# Altium schematic sheet EVK-NORA-W4_SCHEM_0002_NORA_Module.SchDoc
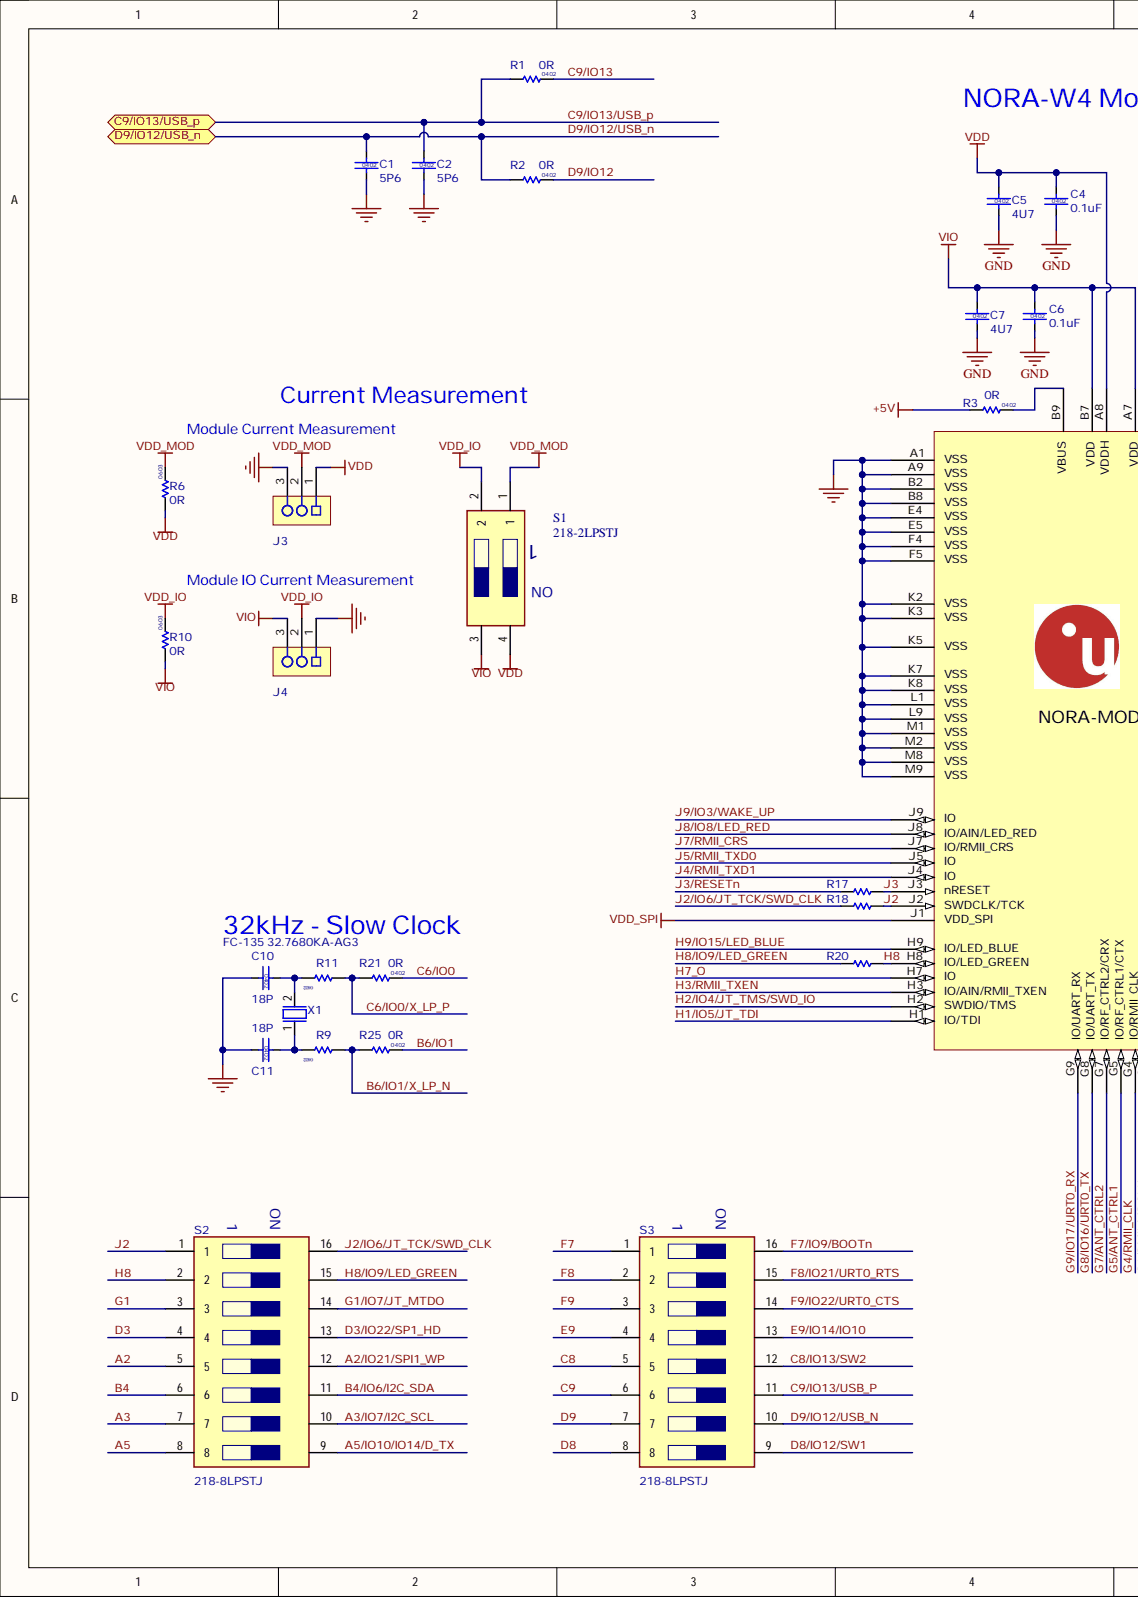
[diagram p0: EVK-NORA-W4_SCHEM_0002_NORA_Module.SchDoc, left]
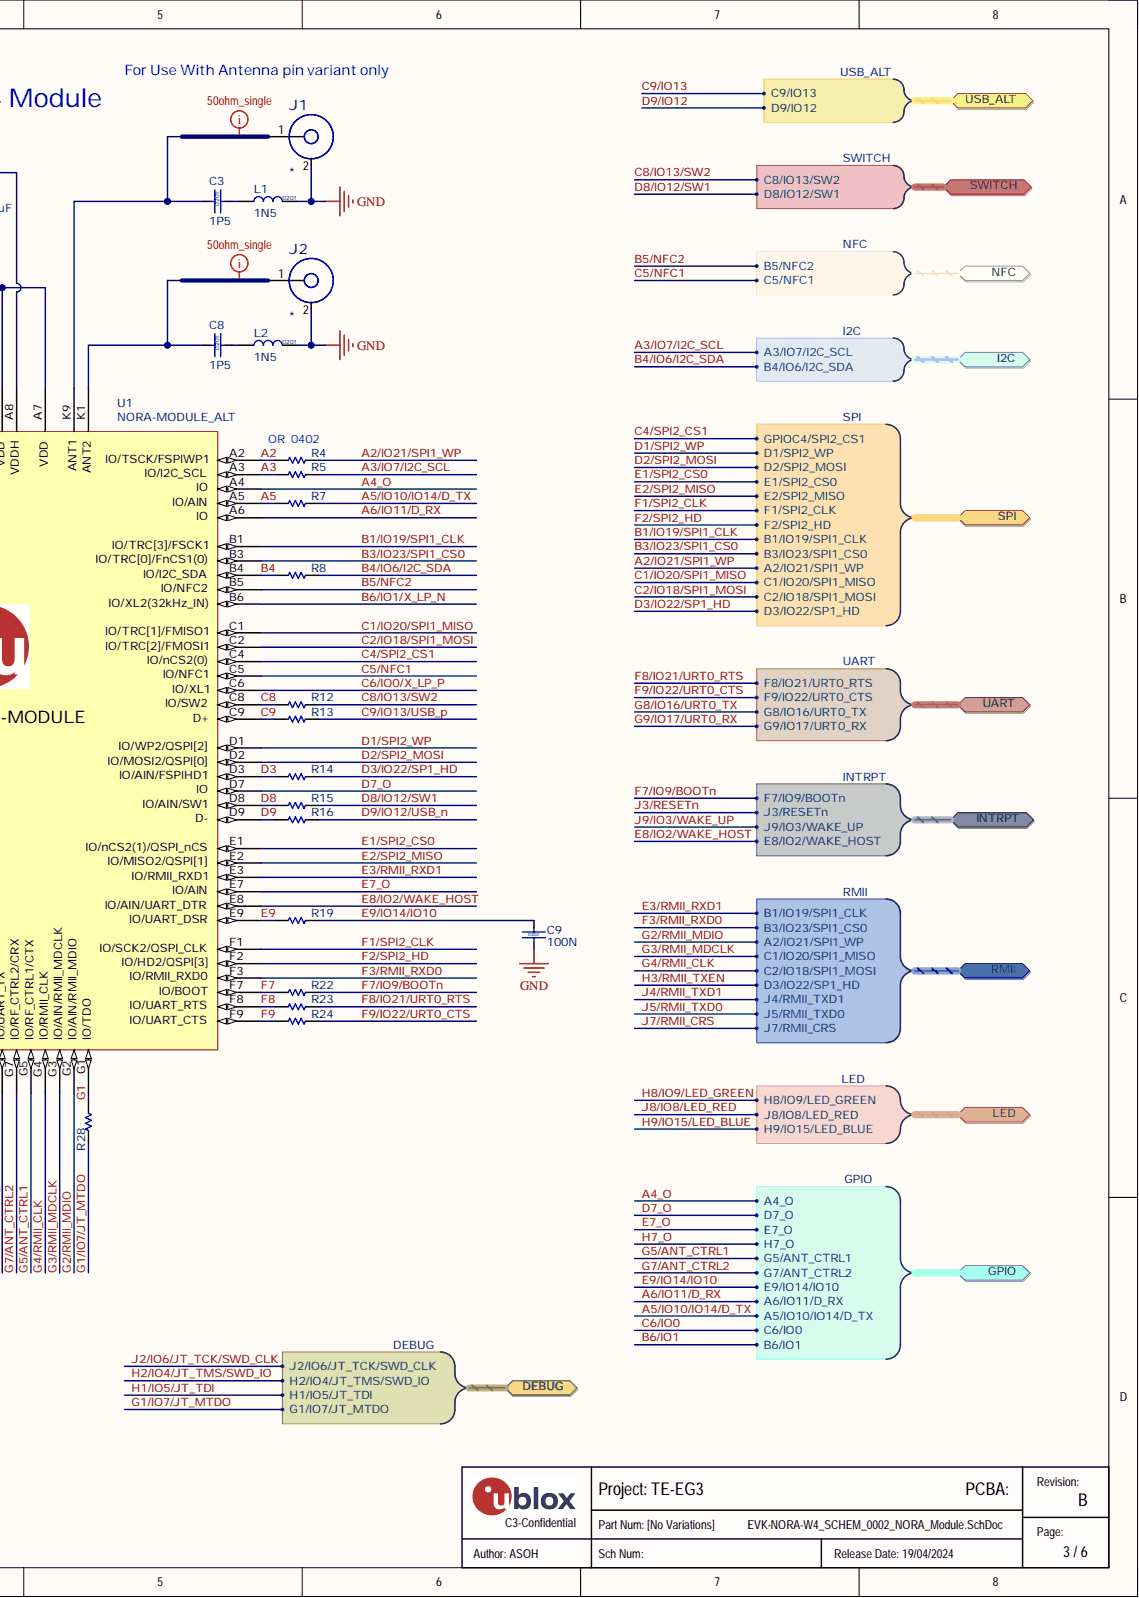
[diagram p1: EVK-NORA-W4_SCHEM_0002_NORA_Module.SchDoc, right]

## Parts
C1  value 5P6  Size: 0402  [Cap_0402_1100Lx600Wx600H_600x600Pad_350G_FLX]  (hidden comment: UBXH01-00040115P6)
C2  value 5P6  Size: 0402  [Cap_0402_1100Lx600Wx600H_600x600Pad_350G_FLX]  (hidden comment: UBXH01-00040115P6)
C3  value 1P5  Size: 0201  [Cap 0201 HD]  (hidden comment: UBXH01-00120021P5)
C4  value 0.1uF  Size: 0402  [Cap 0402 HD]  (hidden comment: 120-000160.1uF)
C5  value 4U7  Size: 0402  [Cap 0402 HD]  (hidden comment: UBXH01-00002434U7)
C6  value 0.1uF  Size: 0402  [Cap 0402 HD]  (hidden comment: 120-000160.1uF)
C7  value 4U7  Size: 0402  [Cap 0402 HD]  (hidden comment: UBXH01-00002434U7)
C8  value 1P5  Size: 0201  [Cap 0201 HD]  (hidden comment: UBXH01-00120021P5)
C9  value 100N  Size: 0402  [Cap 0402 HD]  (hidden comment: UBXH01-2021002100N)
C10  value 18P  Size: 0402  [Cap_0402_1100Lx600Wx600H_600x600Pad_350G_FLX]  (hidden comment: UBXH01-000401718P)
C11  value 18P  Size: 0402  [Cap_0402_1100Lx600Wx600H_600x600Pad_350G_FLX]  (hidden comment: UBXH01-000401718P)
J1  130-00067  [U.FL_3Pin]  (value not drawn)
J2  130-00067  [U.FL_3Pin]  (value not drawn)
J3  UBXH04-0000792  [HDR-0.1P-3Pin-1Row-SMT]  (value not drawn)
J4  UBXH04-0000792  [HDR-0.1P-3Pin-1Row-SMT]  (value not drawn)
L1  value 1N5  Size: 0201  [IND_0201_630Lx330Wx330H_300x300Pad_250G_FLX]  (hidden comment: UBXH09-00000301N5)
L2  value 1N5  Size: 0201  [IND_0201_630Lx330Wx330H_300x300Pad_250G_FLX]  (hidden comment: UBXH09-00000301N5)
R1  value 0R  Size: 0402  [RES 0402 HD]  (hidden comment: UBXH18-00030020R)
R2  value 0R  Size: 0402  [RES 0402 HD]  (hidden comment: UBXH18-00030020R)
R3  value 0R  Size: 0402  [RES 0402 HD]  (hidden comment: UBXH18-00030020R)
R4  Size: 0402  [RES 0402 HD]  (hidden comment: UBXH18-00030020R)
R5  UBXH18-00030020R  [RES 0402 HD]  (value not drawn)
R6  value 0R  Size: 0603  [RES_0603_1700Lx950Wx600H_600x1000Pad_800G_FLX]  (hidden comment: UBXH18-00030010R)
R7  UBXH18-00030020R  [RES 0402 HD]  (value not drawn)
R8  UBXH18-00030020R  [RES 0402 HD]  (value not drawn)
R9  Size: 0402  [RES_0402_1100Lx600Wx400H_500x600Pad_400G_FLX]  (hidden comment: UBXH18-00030020R)
R10  value 0R  Size: 0603  [RES_0603_1700Lx950Wx600H_600x1000Pad_800G_FLX]  (hidden comment: UBXH18-00030010R)
R11  Size: 0402  [RES_0402_1100Lx600Wx400H_500x600Pad_400G_FLX]  (hidden comment: UBXH18-00030020R)
R12  UBXH18-00030020R  [RES 0402 HD]  (value not drawn)
R13  UBXH18-00030020R  [RES 0402 HD]  (value not drawn)
R14  UBXH18-00030020R  [RES_0402_1100Lx600Wx400H_500x600Pad_400G_FLX]  (value not drawn)
R15  UBXH18-00030020R  [RES 0402 HD]  (value not drawn)
R16  UBXH18-00030020R  [RES 0402 HD]  (value not drawn)
R17  UBXH18-00030020R  [RES_0402_1100Lx600Wx400H_500x600Pad_400G_FLX]  (value not drawn)
R18  UBXH18-00030020R  [RES 0402 HD]  (value not drawn)
R19  UBXH18-00030020R  [RES 0402 HD]  (value not drawn)
R20  UBXH18-00030020R  [RES 0402 HD]  (value not drawn)
R21  value 0R  Size: 0402  [RES 0402 HD]  (hidden comment: UBXH18-00030020R)
R22  UBXH18-00030020R  [RES 0402 HD]  (value not drawn)
R23  UBXH18-00030020R  [RES 0402 HD]  (value not drawn)
R24  UBXH18-00030020R  [RES 0402 HD]  (value not drawn)
R25  value 0R  Size: 0402  [RES 0402 HD]  (hidden comment: UBXH18-00030020R)
R28  UBXH18-00030020R  [RES 0402 HD]  (value not drawn)
S1  218-2LPSTJ  [2182LPSTJ]
S2  218-8LPSTJ  [2188LPSTJ]
S3  218-8LPSTJ  [2188LPSTJ]
U1  NORA-MODULE_ALT  [NORA_LGA82R_1430X1040X180_ANT]
X1  100-00005  [XTAL_3215]  (value not drawn)

## Ports
C9/IO13/USB_p, D9/IO12/USB_n, DEBUG, DEBUG.G1/IO7/JT_MTDO, DEBUG.H1/IO5/JT_TDI, DEBUG.H2/IO4/JT_TMS/SWD_IO, DEBUG.J2/IO6/JT_TCK/SWD_CLK, GPIO, GPIO.A4_O, GPIO.A5/IO10/IO14/D_TX, GPIO.A6/IO11/D_RX, GPIO.B6/IO1, GPIO.C6/IO0, GPIO.D7_O, GPIO.E7_O, GPIO.E9/IO14/IO10, GPIO.G5/ANT_CTRL1, GPIO.G7/ANT_CTRL2, GPIO.H7_O, I2C, I2C.A3/IO7/I2C_SCL, I2C.B4/IO6/I2C_SDA, INTRPT, INTRPT.E8/IO2/WAKE_HOST, INTRPT.F7/IO9/BOOTn, INTRPT.J3/RESETn, INTRPT.J9/IO3/WAKE_UP, LED, LED.H8/IO9/LED_GREEN, LED.H9/IO15/LED_BLUE, LED.J8/IO8/LED_RED, NFC, NFC.B5/NFC2, NFC.C5/NFC1, RMII, RMII.A2/IO21/SPI1_WP, RMII.B1/IO19/SPI1_CLK, RMII.B3/IO23/SPI1_CS0, RMII.C1/IO20/SPI1_MISO, RMII.C2/IO18/SPI1_MOSI, RMII.D3/IO22/SP1_HD, RMII.J4/RMII_TXD1, RMII.J5/RMII_TXD0, RMII.J7/RMII_CRS, SPI, SPI.A2/IO21/SPI1_WP, SPI.B1/IO19/SPI1_CLK, SPI.B3/IO23/SPI1_CS0, SPI.C1/IO20/SPI1_MISO, SPI.C2/IO18/SPI1_MOSI, SPI.D1/SPI2_WP, SPI.D2/SPI2_MOSI, SPI.D3/IO22/SP1_HD, SPI.E1/SPI2_CS0, SPI.E2/SPI2_MISO, SPI.F1/SPI2_CLK, SPI.F2/SPI2_HD, SPI.GPIOC4/SPI2_CS1, SWITCH, SWITCH.C8/IO13/SW2, SWITCH.D8/IO12/SW1, UART, UART.F8/IO21/URT0_RTS, UART.F9/IO22/URT0_CTS, UART.G8/IO16/URT0_TX, UART.G9/IO17/URT0_RX, USB_ALT, USB_ALT.C9/IO13, USB_ALT.D9/IO12

## Nets
+5V: R3.1
GND: C1.2 C2.2 C4.2 C5.2 C6.2 C7.2 C9.1 C10.2 C11.2 J1.2 J2.2 J3.3 J4.1 L1.2 L2.2 U1.A1(VSS) U1.A9(VSS) U1.B2(VSS) U1.B8(VSS) U1.E4(VSS) U1.E5(VSS) U1.F4(VSS) U1.F5(VSS) U1.K2(VSS) U1.K3(VSS) U1.K5(VSS) U1.K7(VSS) U1.K8(VSS) U1.L1(VSS) U1.L9(VSS) U1.M1(VSS) U1.M2(VSS) U1.M8(VSS) U1.M9(VSS)
VDD: C4.1 C5.1 J3.1 R6.1 S1.4 U1.A8(VDDH)
VDD_IO: J4.2 R10.2 S1.2
VDD_MOD: J3.2 R6.2 S1.1
VDD_SPI: U1.J1(VDD_SPI)
VIO: C6.1 C7.1 J4.3 R10.1 S1.3 U1.A7(VDD) U1.B7(VDD)
A2: R4.2 S2.5 U1.A2(IO/TSCK/FSPIWP1)
A2/IO21/SPI1_WP: R4.1 S2.12 ; port SPI
A3: R5.2 S2.7 U1.A3(IO/I2C_SCL)
A3/IO7/I2C_SCL: R5.1 S2.10 ; port I2C
A4_O: U1.A4(IO) ; port GPIO
A5: R7.2 S2.8 U1.A5(IO/AIN)
A5/IO10/IO14/D_TX: R7.1 S2.9 ; port GPIO
A6/IO11/D_RX: U1.A6(IO) ; port GPIO
B1/IO19/SPI1_CLK: U1.B1(IO/TRC[3]/FSCK1) ; port SPI
B3/IO23/SPI1_CS0: U1.B3(IO/TRC[0]/FnCS1(0)) ; port SPI
B4: R8.2 S2.6 U1.B4(IO/I2C_SDA)
B4/IO6/I2C_SDA: R8.1 S2.11 ; port I2C
B5/NFC2: U1.B5(IO/NFC2) ; port NFC
B6/IO1: R25.2 ; port GPIO
B6/IO1/X_LP_N: R9.1 R25.1 U1.B6(IO/XL2(32kHz_IN))
C1/IO20/SPI1_MISO: U1.C1(IO/TRC[1]/FMISO1) ; port SPI
C2/IO18/SPI1_MOSI: U1.C2(IO/TRC[2]/FMOSI1) ; port SPI
C4/SPI2_CS1: U1.C4(IO/nCS2(0)) ; port SPI
C5/NFC1: U1.C5(IO/NFC1) ; port NFC
C6/IO0: R21.2 ; port GPIO
C6/IO0/X_LP_P: R11.1 R21.1 U1.C6(IO/XL1)
C8: R12.2 S3.5 U1.C8(IO/SW2)
C8/IO13/SW2: R12.1 S3.12 ; port SWITCH
C9: R13.2 S3.6 U1.C9(D+)
C9/IO13: R1.2 ; port USB_ALT
C9/IO13/USB_p: C2.1 R1.1 R13.1 S3.11 ; port C9/IO13/USB_p
D1/SPI2_WP: U1.D1(IO/WP2/QSPI[2]) ; port SPI
D2/SPI2_MOSI: U1.D2(IO/MOSI2/QSPI[0]) ; port SPI
D3: R14.2 S2.4 U1.D3(IO/AIN/FSPIHD1)
D3/IO22/SP1_HD: R14.1 S2.13 ; port SPI
D7_O: U1.D7(IO) ; port GPIO
D8: R15.2 S3.8 U1.D8(IO/AIN/SW1)
D8/IO12/SW1: R15.1 S3.9 ; port SWITCH
D9: R16.2 S3.7 U1.D9(D-)
D9/IO12: R2.2 ; port USB_ALT
D9/IO12/USB_n: C1.1 R2.1 R16.1 S3.10 ; port D9/IO12/USB_n
E1/SPI2_CS0: U1.E1(IO/nCS2(1)/QSPI_nCS) ; port SPI
E2/SPI2_MISO: U1.E2(IO/MISO2/QSPI[1]) ; port SPI
E3/RMII_RXD1: U1.E3(IO/RMII_RXD1) ; port RMII
E7_O: U1.E7(IO/AIN) ; port GPIO
E8/IO2/WAKE_HOST: U1.E8(IO/AIN/UART_DTR) ; port INTRPT
E9: R19.2 S3.4 U1.E9(IO/UART_DSR)
E9/IO14/IO10: C9.2 R19.1 S3.13 ; port GPIO
F1/SPI2_CLK: U1.F1(IO/SCK2/QSPI_CLK) ; port SPI
F2/SPI2_HD: U1.F2(IO/HD2/QSPI[3]) ; port SPI
F3/RMII_RXD0: U1.F3(IO/RMII_RXD0) ; port RMII
F7: R22.2 S3.1 U1.F7(IO/BOOT)
F7/IO9/BOOTn: R22.1 S3.16 ; port INTRPT
F8: R23.2 S3.2 U1.F8(IO/UART_RTS)
F8/IO21/URT0_RTS: R23.1 S3.15 ; port UART
F9: R24.2 S3.3 U1.F9(IO/UART_CTS)
F9/IO22/URT0_CTS: R24.1 S3.14 ; port UART
G1: R28.1 S2.3 U1.G1(IO/TDO)
G1/IO7/JT_MTDO: R28.2 S2.14 ; port DEBUG
G2/RMII_MDIO: U1.G2(IO/AIN/RMII_MDIO) ; port RMII
G3/RMII_MDCLK: U1.G3(IO/AIN/RMII_MDCLK) ; port RMII
G4/RMII_CLK: U1.G4(IO/RMII_CLK) ; port RMII
G5/ANT_CTRL1: U1.G5(IO/RF_CTRL1/CTX) ; port GPIO
G7/ANT_CTRL2: U1.G7(IO/RF_CTRL2/CRX) ; port GPIO
G8/IO16/URT0_TX: U1.G8(IO/UART_TX) ; port UART
G9/IO17/URT0_RX: U1.G9(IO/UART_RX) ; port UART
H1/IO5/JT_TDI: U1.H1(IO/TDI) ; port DEBUG
H2/IO4/JT_TMS/SWD_IO: U1.H2(SWDIO/TMS) ; port DEBUG
H3/RMII_TXEN: U1.H3(IO/AIN/RMII_TXEN) ; port RMII
H7_O: U1.H7(IO) ; port GPIO
H8: R20.1 S2.2 U1.H8(IO/LED_GREEN)
H8/IO9/LED_GREEN: R20.2 S2.15 ; port LED
H9/IO15/LED_BLUE: U1.H9(IO/LED_BLUE) ; port LED
J2: R18.1 S2.1 U1.J2(SWDCLK/TCK)
J2/IO6/JT_TCK/SWD_CLK: R18.2 S2.16 ; port DEBUG
J3: R17.1 U1.J3(nRESET)
J3/RESETn: R17.2 ; port INTRPT
J4/RMII_TXD1: U1.J4(IO) ; port RMII
J5/RMII_TXD0: U1.J5(IO) ; port RMII
J7/RMII_CRS: U1.J7(IO/RMII_CRS) ; port RMII
J8/IO8/LED_RED: U1.J8(IO/AIN/LED_RED) ; port LED
J9/IO3/WAKE_UP: U1.J9(IO) ; port INTRPT
NetC3_1: C3.1 J1.1 U1.K9(ANT1)
NetC3_2: C3.2 L1.1
NetC8_1: C8.1 J2.1 U1.K1(ANT2)
NetC8_2: C8.2 L2.1
NetC10_1: C10.1 R11.2 X1.2
NetC11_1: C11.1 R9.2 X1.1
NetR3_2: R3.2 U1.B9(VBUS)

## Library data of the parts
C1 C2 = UBXH01-0004011: CAP CER C0G 0402 5P6 +/-0.25P 25V; Manufacturer: Murata Manufacturing Company, Ltd.; Manufacturer P/N: GRM1555C1H5R6CZ01; Database Table Name: Passive - Capacitor; Editor: roland.rust; Env: ROHS; Mfg P/N Released: Obsolete; Mfg P/N Split: 0; Perferred: PREF; Permitted Use: Volume Products; Component Kind: Standard; Library Name: UBX_Parts_DB_Lib.DbLib; Manufacturer 2: Murata Manufacturing Company, Ltd.; Manufacturer P/N 2: GRM1555C1E5R6CZ01; Max Voltage: 25V; Mfg P/N Released 2: Obsolete; Mfg P/N Split 2: 0; Mfg P/N Status: Obsolete; Mfg P/N Status 2: Obsolete; Part Number: UBXH01-0004011; Part Type: Capacitor; RefDes: C; Release Data: 2007-10-22T12:46:36; Signal Integrity: Cap Semi; Simulation: CAP; Tolerance: +/-0.25P; UBX Wiki: https://wiki.u-blox.com/bin/view/Operations/PartDetails?index=5160; Released by: roland.rust
C3 C8 = UBXH01-0012002: CAP CER C0G 0201 1P5 +/-0.25P 25V; Manufacturer: Murata Manufacturing Company, Ltd.; Manufacturer P/N: GRM0335C1E1R5CA01; Database Table Name: Passive - Capacitor; Editor: roland.rust; Env: ROHS; Mfg P/N Released: Yes; Mfg P/N Split: 100; Mfg P/N Status: Active; Perferred: PREF; Permitted Use: Volume Products; Component Kind: Standard; Library Name: UBX_Parts_DB_Lib.DbLib; Manufacturer 2: Murata Manufacturing Company, Ltd.; Manufacturer P/N 2: GRM0335C1E1R5C; Max Voltage: 25V; Mfg P/N Released 2: Obsolete; Mfg P/N Split 2: 0; Mfg P/N Status 2: Active; Part Number: UBXH01-0012002; Part Type: Capacitor; RefDes: C; Release Data: 2009-03-23T10:34:14; Signal Integrity: Cap Semi; Simulation: CAP; Tolerance: +/-0.25P; UBX Wiki: https://wiki.u-blox.com/bin/view/Operations/PartDetails?index=5072; Released by: roland.rust
C4 C6 = 120-00016: Cap, Ceramic 0.1uF 10V 10% X5R 0402; Manufacturer: Murata Electronics North America; Manufacturer P/N: GRM155R61A104KA01J; Part Name: CAP CER 0.1UF 10V 10% X5R 0402; Component Mounting Type: SMT; Database Table Name: Ceramic Capacitor; Item_Phase: Production; Tolerance: +/- 10%; Use in new designs: Yes; Arena Link: https://app.bom.com/a/GYHPV2L2L43KRMBK?v=spec.summary; Component Kind: Standard; Height: 0.55mm; Manufacturer 2: Yageo; Manufacturer P/N 2: CC0402KRX5R6BB104; Part Number: 120-00016; Part_Type: Ceramic Capacitor; Pins: 2; Revision: 0A; Signal Integrity: Cap Semi; Simulation: CAP; Supplier 1: Digi-Key; Supplier 2: Digi-Key; Supplier Part Number 1: 490-6297-1-ND; Supplier Part Number 2: 311-1336-1-ND; Temperature Coefficient: X5R; Voltage: 10V; Library Name: Arena_Parts_DB_Lib.DbLib
C5 C7 = UBXH01-0000243: CAP CER X5R 0402 4U7 20% 10V; Manufacturer: Murata Manufacturing Company, Ltd.; Manufacturer P/N: GRM155R61A475MEAA; Database Table Name: Passive - Capacitor; Editor: martin.pilik; Env: GREEN; Mfg P/N Released: Yes; Mfg P/N Split: 100; Mfg P/N Status: Active; Perferred: PREF; Permitted Use: Volume Products; Released by: fabrice.bret-dibat; Component Kind: Standard; Library Name: UBX_Parts_DB_Lib.DbLib; Max Operating Temp: 85°C; Max Voltage: 10V; Mfg P/N Datasheet: https://wiki.u-blox.com/bin/view/Generic/Docs/DocumentSummary?index=24302; Min Operating Temp: -40°C; Part Number: UBXH01-0000243; Part Type: Capacitor; RefDes: C; Release Data: 2021-05-10 12:57:47.325652; Signal Integrity: Cap Semi; Simulation: CAP; Tolerance: 20%; UBX Wiki: https://wiki.u-blox.com/bin/view/Operations/PartDetails?index=24303
C9 = UBXH01-2021002: CAP CER X5R 0402 100N 10% 10V; Manufacturer: Murata Manufacturing Company, Ltd.; Manufacturer P/N: GRM155R61A104KA01; Database Table Name: Passive - Capacitor; Editor: roland.rust; Env: GREEN; Mfg P/N Released: Yes; Mfg P/N Split: 100; Mfg P/N Status: NRND; Perferred: PREF; Permitted Use: Volume Products; Component Kind: Standard; Library Name: UBX_Parts_DB_Lib.DbLib; Manufacturer 2: Samsung Electro-Mechanics; Manufacturer P/N 2: CL05A104KP5NNN; Max Voltage: 10V; Mfg P/N Datasheet 2: https://wiki.u-blox.com/bin/view/Generic/Docs/DocumentSummary?index=28113; Mfg P/N Released 2: Yes; Mfg P/N Split 2: 0; Mfg P/N Status 2: Active; Part Number: UBXH01-2021002; Part Type: Capacitor; RefDes: C; Release Data: 2005-07-22T13:59:44; Signal Integrity: Cap Semi; Simulation: CAP; Tolerance: 10%; UBX Wiki: https://wiki.u-blox.com/bin/view/Operations/PartDetails?index=5759; Released by: roland.rust
C10 C11 = UBXH01-0004017: CAP CER C0G 0402 18P 5% 25V; Manufacturer: Murata Manufacturing Company, Ltd.; Manufacturer P/N: GRM1555C1H180JA01; Database Table Name: Passive - Capacitor; Editor: doris.zahnd; Env: ROHS; Mfg P/N Released: Yes; Mfg P/N Split: 100; Mfg P/N Status: NRND; Perferred: PREF; Permitted Use: Volume Products; Released by: daniel.hurni; Component Kind: Standard; Library Name: UBX_Parts_DB_Lib.DbLib; Manufacturer 2: Murata Manufacturing Company, Ltd.; Manufacturer 3: Murata Manufacturing Company, Ltd.; Manufacturer P/N 2: GRM1555C1E180JZ01; Manufacturer P/N 3: GRM1555C1H180JZ01; Max Operating Temp: 125°C; Max Voltage: 25V; Mfg P/N Released 2: Yes; Mfg P/N Released 3: Yes; Mfg P/N Split 2: 0; Mfg P/N Split 3: 0; Mfg P/N Status 2: Obsolete; Mfg P/N Status 3: Obsolete; Min Operating Temp: -55°C; Part Number: UBXH01-0004017; Part Type: Capacitor; RefDes: C; Release Data: 2009-12-03T17:48:42; Signal Integrity: Cap Semi; Simulation: CAP; Tolerance: 5%; UBX Wiki: https://wiki.u-blox.com/bin/view/Operations/PartDetails?index=5178
J1 J2 = 130-00067: U.FL jack, SMA 50 ohm, 3 pin, SMT; Manufacturer 1: Hirose Electric Co Ltd; Manufacturer Part Number 1: U.FL-R-SMT(10); Part Name: CON RF UMC U.FL Jack 3Pin SMT; Database Table Name: Connector; Item Phase: In Production; UBX Code: UBXH04-0001026; Use in new designs: Yes; Voltage: None; Component Kind: Standard; Diameter: None; Height: 1.25mm; Manufacturer 2: Dongguan Qintai Electronics Co, Ltd.; Manufacturer Part Number 2: MHF-RT-1; Max Operating Temp: 90; Min Operating Temp: -40; Part Number: 130-00067; Pins: 3; Revision: 0A; Supplier 1: Digi-Key; Supplier 2: Rayson Technology co., ltd; Supplier Part Number 1: H9161CT-ND; Supplier Part Number 2: MHF-RT-1; Library Name: Arena_Parts_DB_Lib.DbLib
J3 J4 = UBXH04-0000792: 1-ROW SMD CONN 100MIL GRID 3 -PIN 100V 3A; Manufacturer: HARWiN; Manufacturer P/N: M20-8770342; Database Table Name: Electromechanical - Connector; Editor: eric.moog; Env: ROHS; Mfg P/N Released: No; Mfg P/N Split: 100; Mfg P/N Status: Active; Permitted Use: Support Products; Value: M20-8770342; Component Kind: Standard; Library Name: UBX_Parts_DB_Lib.DbLib; Max Current: 3A; Max Operating Temp: 105°C; Max Voltage: 100V; Mfg P/N Datasheet: https://wiki.u-blox.com/bin/view/Generic/Docs/DocumentSummary?index=28457; Min Operating Temp: -40°C; Part Number: UBXH04-0000792; Part Type: Connector; Perferred: N/A; RefDes: J; UBX Wiki: https://wiki.u-blox.com/bin/view/Operations/PartDetails?index=38569
L1 L2 = UBXH09-0000030: IND MURATA LQP03T_02 0201 1N5 +/-0.1N 600mA; Manufacturer: Murata Manufacturing Company, Ltd.; Manufacturer P/N: LQP03TN1N5B02; Database Table Name: Passive - Inductor; Editor: massimo.pros; Env: ROHS; Mfg P/N Released: Yes; Mfg P/N Split: 100; Mfg P/N Status: Active; Perferred: PREF; Permitted Use: Volume Products; Released by: massimo.vlacci; Component Kind: Standard; Library Name: UBX_Parts_DB_Lib.DbLib; Max Current: 600mA; Mfg P/N Datasheet: https://wiki.u-blox.com/bin/view/Generic/Docs/DocumentSummary?index=11499; Part Number: UBXH09-0000030; Part Type: Inductor; RefDes: L; Release Data: 2011-06-21T11:29:10; Tolerance: +/-0.1N; UBX Wiki: https://wiki.u-blox.com/bin/view/Operations/PartDetails?index=13044
R1-R3 R9 R11 R14 R17 R21 R25 = UBXH18-0003002: RES THICK FILM CHIP 0402 0R 0 100mW; Manufacturer: KOA; Manufacturer P/N: RK73Z1ETTD; Database Table Name: Passive - Resistor; Editor: charlotte.biber; Env: ROHS; Mfg P/N Released: Yes; Mfg P/N Split: 100; Mfg P/N Status: NRND; Perferred: PREF; Permitted Use: Volume Products; Released by: peter.mueller; Tolerance: 0; Component Kind: Standard; Library Name: UBX_Parts_DB_Lib.DbLib; Manufacturer 2: YAGEO; Manufacturer P/N 2: 2322_705_91001_L; Max Power: 100mW; Mfg P/N Released 2: Yes; Mfg P/N Split 2: 0; Part Number: UBXH18-0003002; Part Type: Resistor; RefDes: R; Signal Integrity: Res; Simulation: RESISTOR; UBX Wiki: https://wiki.u-blox.com/bin/view/Operations/PartDetails?index=6611
  R1-R3 R21 R25: Mfg P/N Status 2: NRND; Release Data: 2012-06-05 09:49:55.978616
  R9 R11: Mfg P/N Status 2: Obsolete; Release Data: 2012-06-05T09:49:55; Value: 0R
  R14 R17: Mfg P/N Status 2: Obsolete; Release Data: 2012-06-05T09:49:55; Size: 0402; Value: 0R
R4 R5 R7 R8 R12 R13 R15 R16 R18-R20 R22-R24 R28 = UBXH18-0003002: RES THICK FILM CHIP 0402 0R 0 100mW; Manufacturer: KOA; Manufacturer P/N: RK73Z1ETTP; Database Table Name: Passive - Resistor; Editor: charlotte.biber; Env: ROHS; Mfg P/N Released: Yes; Mfg P/N Split: 100; Mfg P/N Status: NRND; Perferred: PREF; Permitted Use: Volume Products; Released by: peter.mueller; Tolerance: 0; Component Kind: Standard; Library Name: UBX_Parts_DB_Lib.DbLib; Manufacturer 2: YAGEO; Manufacturer P/N 2: 2322_705_91001_L; Max Power: 100mW; Mfg P/N Released 2: Yes; Mfg P/N Split 2: 0; Mfg P/N Status 2: NRND; Part Number: UBXH18-0003002; Part Type: Resistor; RefDes: R; Release Data: 2012-06-05 09:49:55.978616; Signal Integrity: Res; Simulation: RESISTOR; UBX Wiki: https://wiki.u-blox.com/bin/view/Operations/PartDetails?index=6611; Value: 0R
  R5 R7 R8 R12 R13 R15 R16 R18-R20 R22-R24 R28: Size: 0402
R6 R10 = UBXH18-0003001: RES THICK FILM CHIP 0603 0R 0 100mW; Manufacturer: YAGEO; Manufacturer P/N: RC0603JR-070RL; Database Table Name: Passive - Resistor; Editor: martin.frauchiger; Env: ROHS; Mfg P/N Released: Yes; Mfg P/N Split: 100; Mfg P/N Status: Active; Perferred: PREF; Permitted Use: Volume Products; Tolerance: 0; Component Kind: Standard; Library Name: UBX_Parts_DB_Lib.DbLib; Max Power: 100mW; Part Number: UBXH18-0003001; Part Type: Resistor; RefDes: R; Release Data: 2005-07-14T10:55:51; Signal Integrity: Res; Simulation: RESISTOR; UBX Wiki: https://wiki.u-blox.com/bin/view/Operations/PartDetails?index=6609; Released by: martin.frauchiger
S1 = 218-2LPSTJ: Switch; Manufacturer_Name: CTS; Arrow Part Number: 218-2LPSTJ; Arrow Price/Stock: https://www.arrow.com/en/products/218-2lpstj/cts; Component Kind: Standard; Datasheet Link: https://www.ctscorp.com/wp-content/uploads/218.pdf; Height: 2.7mm; Library Name: SamacSys.SchLib; Manufacturer_Part_Number: 218-2LPSTJ; Mouser Part Number: 774-2182LPSTJ; Mouser Price/Stock: https://www.mouser.co.uk/ProductDetail/CTS-Electronic-Components/218-2LPSTJ?qs=qNzHFtQhdJ8h31nbRNBSWA%3D%3D
S2 S3 = 218-8LPSTJ: Switch; Manufacturer_Name: CTS; Arrow Part Number: 218-8LPSTJ; Arrow Price/Stock: https://www.arrow.com/en/products/218-8lpstj/cts; Component Kind: Standard; Datasheet Link: https://www.ctscorp.com/wp-content/uploads/218.pdf; Height: 2.7mm; Library Name: SamacSys.SchLib; Manufacturer_Part_Number: 218-8LPSTJ; Mouser Part Number: 774-2188LPSTJ; Mouser Price/Stock: https://www.mouser.co.uk/ProductDetail/CTS-Electronic-Components/218-8LPSTJ?qs=hDoPxpGr7lfoBDyeqUpd9g%3D%3D
U1 = NORA-MODULE_ALT: u-blox NORA module generic symbol for use within module designs - alternate version; Part Description: NORA MODULE FOOTPRINT; Body Size: 14.3 mm x 10.4 mm; Component Kind: Standard; Height: 0; Library Name: NORA.SchLib; Manufacturer: u-blox; Manufacturer P/N: NORA; Part Name: NORA; Part Number: NORA; Part_Type: Module; Value: NORA
X1 = 100-00005: XTAL, 2Pin, 32.768kHz, 7pF 20ppm; Manufacturer: Epson; Manufacturer P/N: FC-135 32.7680KA-AG3; Part Name: XTAL 32.768kHz 7pF 20ppm 3215; Body Size: 3.2mm x 1.5mm; Component Mounting Type: SMT; Database Table Name: Crystal/Oscillator; Item_Phase: Production; MFG Package: 2-SMD; Use in new designs: Yes; Arena Link: https://app.bom.com/a/L3MU07Q7Q98O5PC8?v=spec.summary; CL: 7pF; Component Kind: Standard; Frequency: 32.768kHz; Frequency Tolerance: 20ppm; Height: 0.9mm; Manufacturer 2: AVX Corporation; Manufacturer P/N 2: ST3215SB32768C0HPWBB; Part Number: 100-00005; Part_Type: Crystal/Oscillator; Pins: 2; Revision: 0C; Supplier 1: Digi-Key; Supplier 2: Digi-Key; Supplier Part Number 1: SER4086CT-ND; Supplier Part Number 2: 1253-1113-1-ND; Library Name: Arena_Parts_DB_Lib.DbLib
